# Altium schematic sheet 2_Power.SchDoc(2_Power)
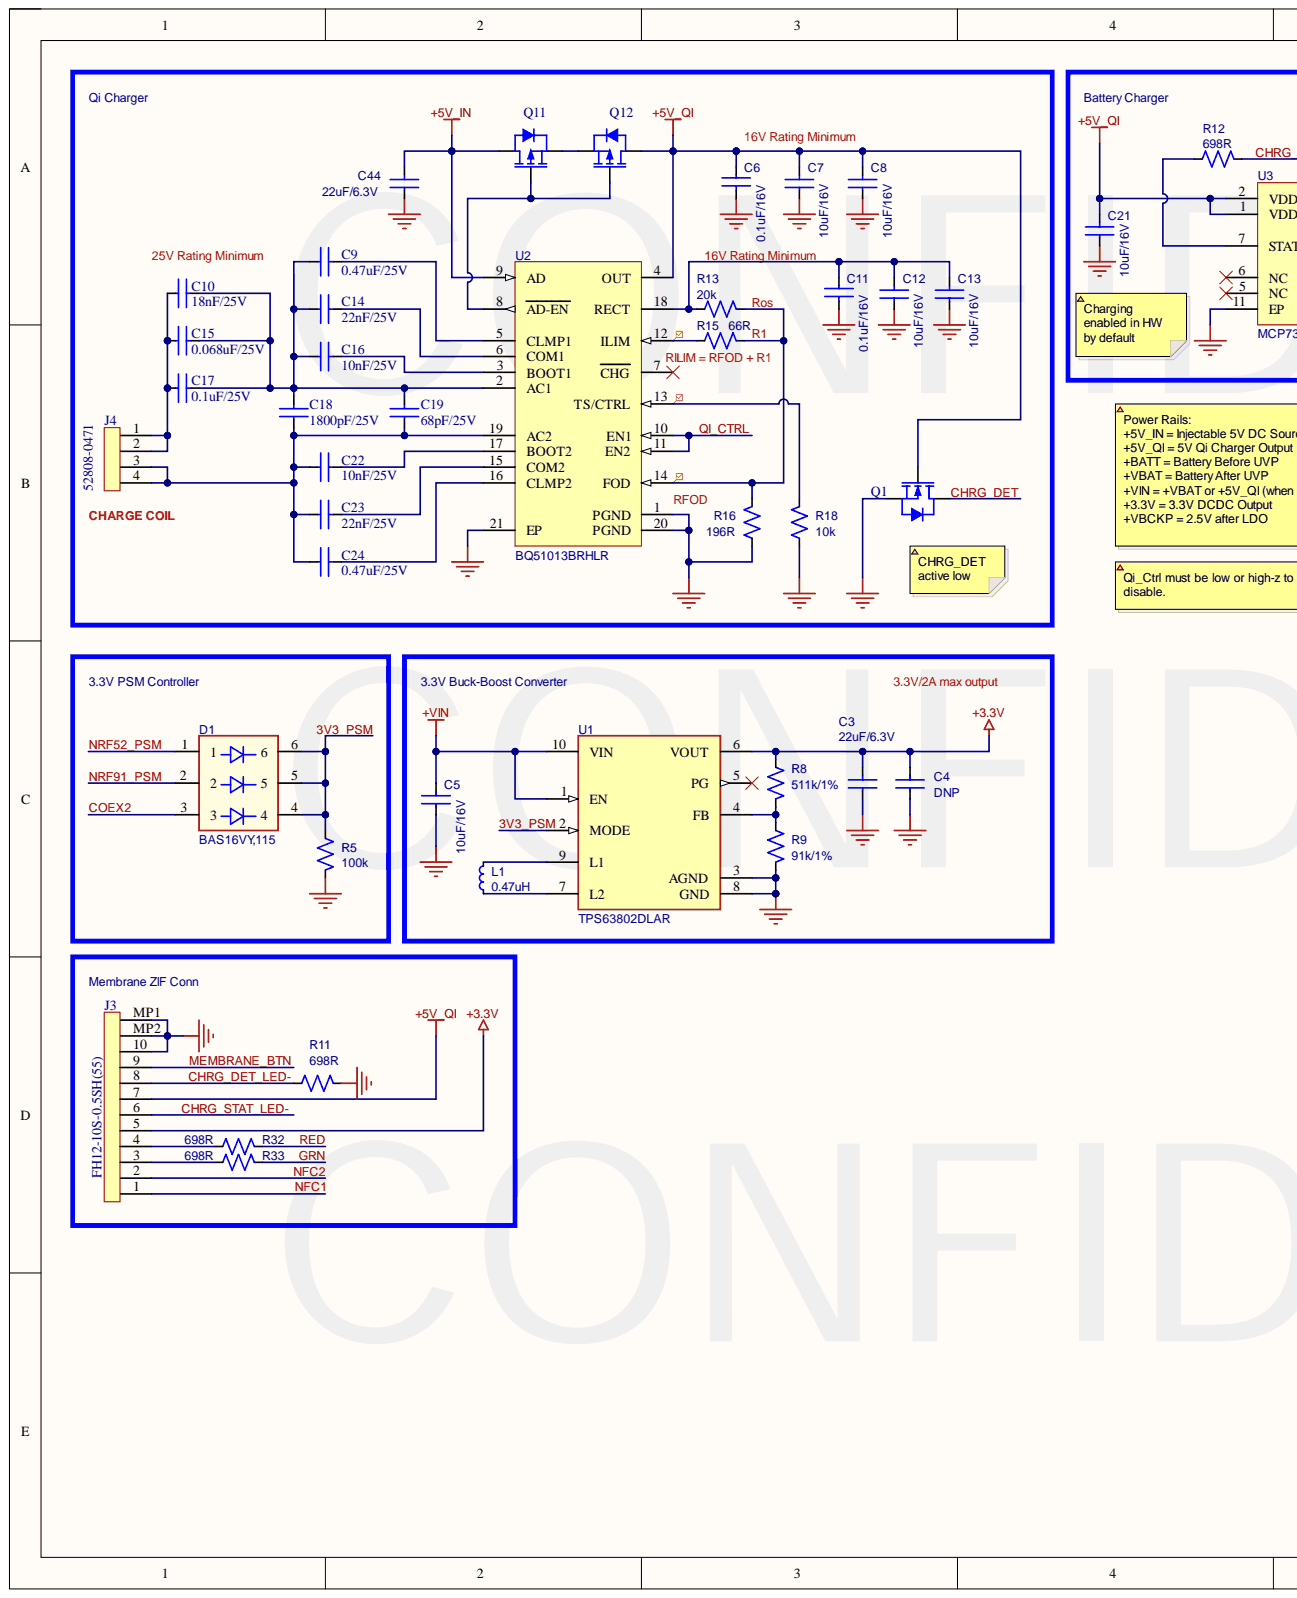
[diagram p0: 2_Power.SchDoc, left]
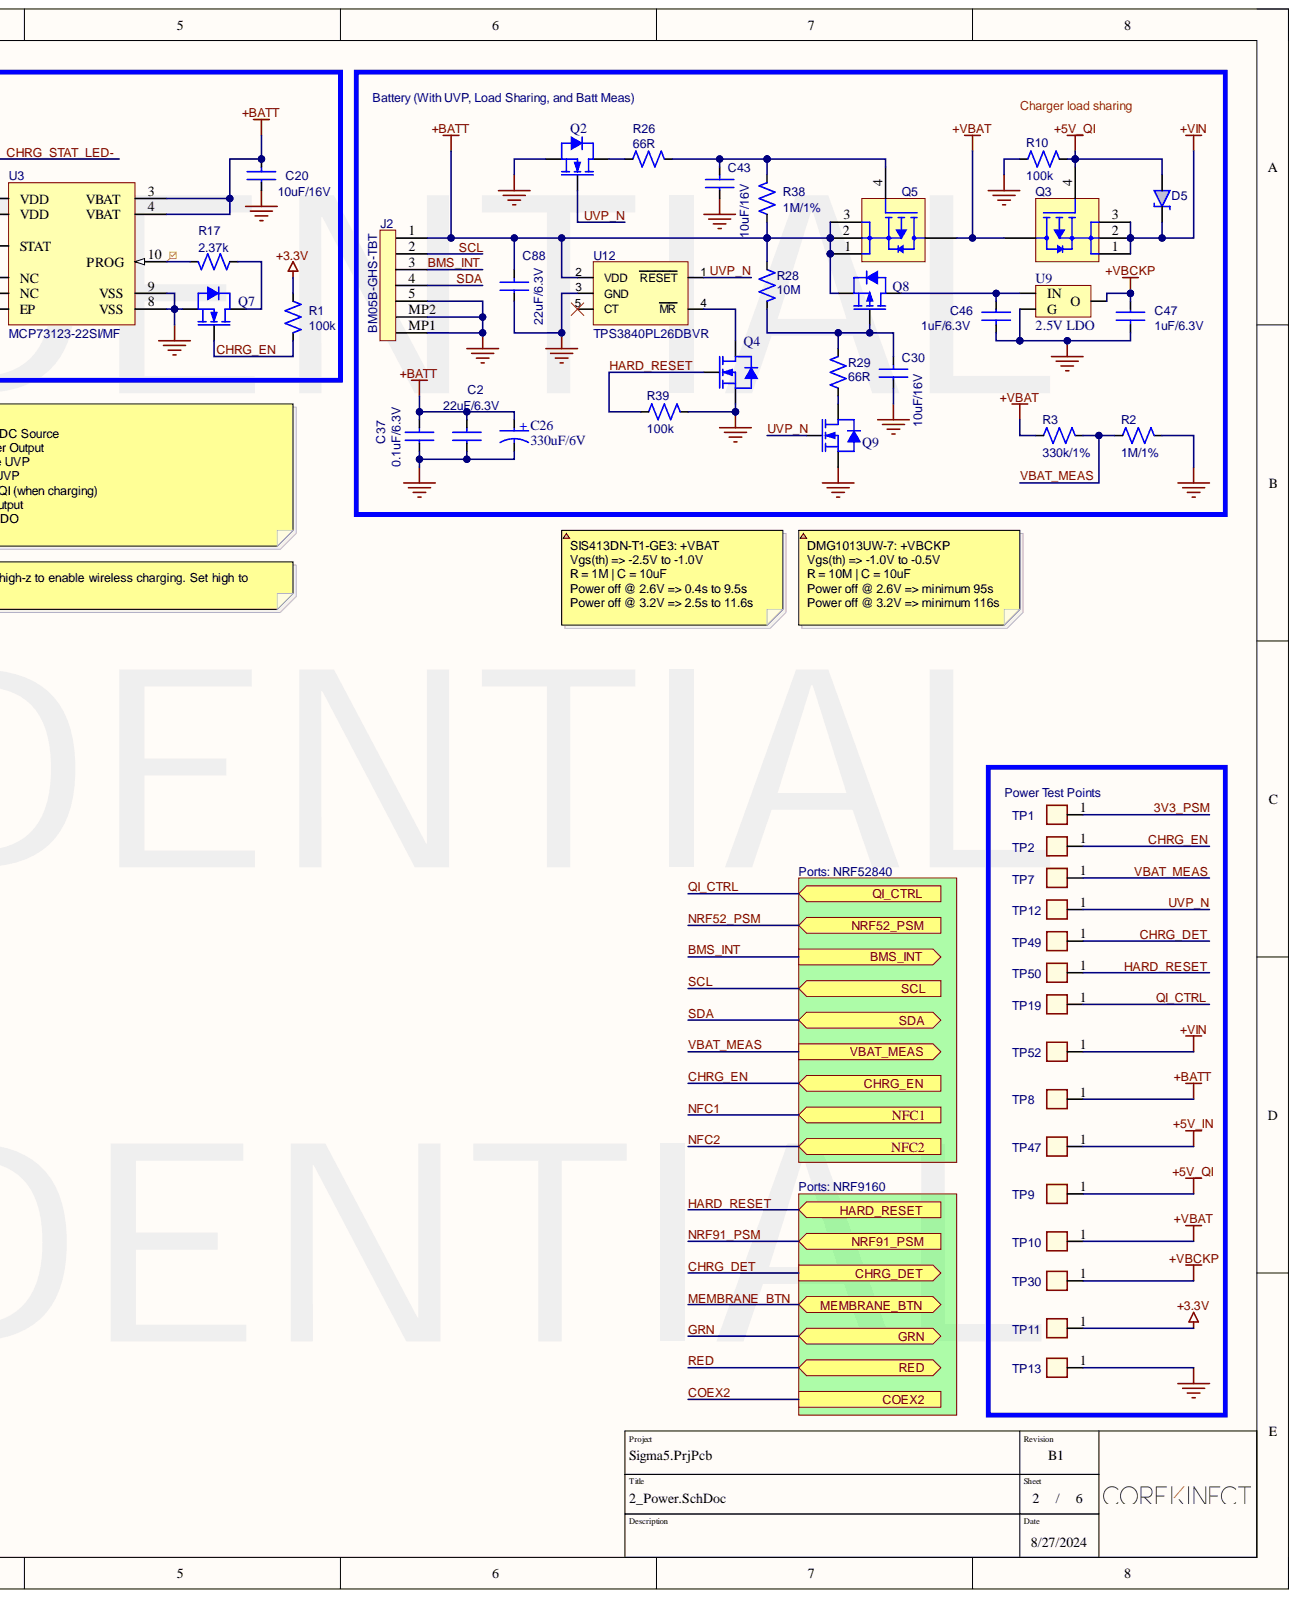
[diagram p1: 2_Power.SchDoc, right]

## Parts
C2  22uF/6.3V  [CAP_0603]
C3  22uF/6.3V  [CAP_0603]
C4  DNP  [CAP_0603]
C5  10uF/16V  [CAP_0603]
C6  0.1uF/16V  [CAP_0402]
C7  10uF/16V  [CAP_0603]
C8  10uF/16V  [CAP_0603]
C9  0.47uF/25V  [CAP_0402]
C10  18nF/25V  [CAP_0402]
C11  0.1uF/16V  [CAP_0402]
C12  10uF/16V  [CAP_0603]
C13  10uF/16V  [CAP_0603]
C14  22nF/25V  [CAP_0402]
C15  0.068uF/25V  [CAP_0402]
C16  10nF/25V  [CAP_0402]
C17  0.1uF/25V  [CAP_0402]
C18  1800pF/25V  [CAP_0402]
C19  68pF/25V  [CAP_0402]
C20  10uF/16V  [CAP_0603]
C21  10uF/16V  [CAP_0603]
C22  10nF/25V  [CAP_0402]
C23  22nF/25V  [CAP_0402]
C24  0.47uF/25V  [CAP_0402]
C26  330uF/6V  [CAP_POLAR_1411]
C30  10uF/16V  [CAP_0603]
C37  0.1uF/6.3V  [CAP_0402]
C43  10uF/16V  [CAP_0603]
C44  22uF/6.3V  [CAP_0603]
C46  1uF/6.3V  [CAP_0402]
C47  1uF/6.3V  [CAP_0402]
C88  22uF/6.3V  [CAP_0603]
D1  BAS16VY,115  [SOT-363]
D5  B140HW-7  [SOD123]  (value not drawn)
J2  BM05B-GHS-TBT  [JST-BM05B-GHS-TBT_V]
J3  FH12-10S-0.5SH(55)  [Hirose_FH12-10S-0.5SH(55)]
J4  52808-0471  [Molex_0528080471]
L1  0.47uH  [TAYO-IND0315_V]
Q1  DMG1012UW-7  [SOT-323]  (value not drawn)
Q2  DMG1012UW-7  [SOT-323]  (value not drawn)
Q3  SIS413DN-T1-GE3  [POWERPAK_1212-8]
Q4  DMG1012UW-7  [SOT-323]  (value not drawn)
Q5  SIS413DN-T1-GE3  [POWERPAK_1212-8]
Q7  DMG1012UW-7  [SOT-323]  (value not drawn)
Q8  DMG1013UW-7  [SOT-323]
Q9  DMG1012UW-7  [SOT-323]  (value not drawn)
Q11  DMG1013UW-7  [SOT-323]
Q12  DMG1013UW-7  [SOT-323]
R1  100k  [RES_0402]
R2  1M/1%  [RES_0402]
R3  330k/1%  [RES_0402]
R5  100k  [RES_0402]
R8  511k/1%  [RES_0402]
R9  91k/1%  [RES_0402]
R10  100k  [RES_0402]
R11  698R  [RES_0402]
R12  698R  [RES_0402]
R13  20k  [RES_0402]
R15  66R  [RES_0402]
R16  196R  [RES_0402]
R17  2.37k  [RES_0402]
R18  10k  [RES_0402]
R26  66R  [RES_0402]
R28  10M  [RES_0402]
R29  66R  [RES_0402]
R32  698R  [RES_0402]
R33  698R  [RES_0402]
R38  1M/1%  [RES_0402]
R39  100k  [RES_0402]
TP1  TP  [SMT_TP35_SM]
TP2  TP  [SMT_TP35_SM]
TP7  TP  [SMT_TP35_SM]
TP8  TP  [SMT_TP35_SM]
TP9  TP  [SMT_TP35_SM]
TP10  TP  [SMT_TP35_SM]
TP11  TP  [SMT_TP35_SM]
TP12  TP  [SMT_TP35_SM]
TP13  TP  [SMT_TP35_SM]
TP19  TP  [SMT_TP35_SM]
TP30  TP  [SMT_TP35_SM]
TP47  TP  [SMT_TP35_SM]
TP49  TP  [SMT_TP35_SM]
TP50  TP  [SMT_TP35_SM]
TP52  TP  [SMT_TP35_SM]
U1  TPS63802DLAR  [FP-DLA0010A-MFG]
U2  BQ51013BRHLR  [BQ51013BRHLR]
U3  MCP73123-22SI/MF  [DFN-MF10]
U9  2.5V LDO  Min Input Voltage: 2.5V  [SOT-23]
U12  TPS3840PL26DBVR  [SOT23-5P95_280X145XL45X37N]

## Ports
BMS_INT, CHRG_DET, CHRG_EN, COEX2, GRN, HARD_RESET, MEMBRANE_BTN, NFC1, NFC2, NRF52_PSM, NRF91_PSM, QI_CTRL, RED, SCL, SDA, VBAT_MEAS

## Nets
+3.3V: C3.2 C4.2 J3.5 R1.1 R8.1 TP11.1 U1.6(VOUT)
+5V_IN: C44.2 Q11.3 TP47.1 U2.9(AD)
+5V_QI: C6.1 C7.2 C8.2 C21.2 D5.2 J3.7 Q1.1 Q3.4 Q12.3 R10.1 TP9.1 U2.4(OUT) U3.1(VDD) U3.2(VDD)
+BATT: C2.2 C20.2 C26.1 C37.2 C88.2 J2.1 Q5.1 Q5.2 Q5.3 Q8.2 R28.1 R38.1 TP8.1 U3.3(VBAT) U3.4(VBAT) U12.2(VDD)
+VBAT: Q3.5-6-7-8 Q5.5-6-7-8 R3.1 TP10.1
+VBCKP: C47.1 TP30.1 U9.2
+VIN: C5.2 D5.1 Q3.1 Q3.2 Q3.3 TP52.1 U1.1(EN) U1.10(VIN)
GND: C2.1 C3.1 C4.1 C5.1 C6.2 C7.1 C8.1 C11.2 C12.1 C13.1 C20.1 C21.1 C26.2 C30.1 C37.1 C43.1 C44.1 C46.2 C47.2 C88.1 J2.5 J2.MP1 J2.MP2 J3.10 J3.MP1 J3.MP2 Q1.2 Q2.2 Q4.2 Q7.2 Q9.2 R2.2 R5.2 R9.2 R10.2 R11.2 R16.1 R18.2 R39.2 TP13.1 U1.3(AGND) U1.8(GND) U2.1(PGND) U2.20(PGND) U2.21(EP) U3.8(VSS) U3.9(VSS) U3.11(EP) U9.1 U12.3(GND)
3V3_PSM: D1.4 D1.5 D1.6 R5.1 TP1.1 U1.2(MODE)
BMS_INT: J2.3 ; port BMS_INT
CHRG_DET: Q1.3 TP49.1 ; port CHRG_DET
CHRG_DET_LED-: J3.8 R11.1
CHRG_EN: Q7.1 R1.2 TP2.1 ; port CHRG_EN
CHRG_STAT_LED-: J3.6 R12.2
COEX2: D1.3 ; port COEX2
GRN: R33.2 ; port GRN
HARD_RESET: Q4.1 R39.1 TP50.1 ; port HARD_RESET
MEMBRANE_BTN: J3.9 ; port MEMBRANE_BTN
NFC1: J3.1 ; port NFC1
NFC2: J3.2 ; port NFC2
NRF52_PSM: D1.1 ; port NRF52_PSM
NRF91_PSM: D1.2 ; port NRF91_PSM
QI_CTRL: TP19.1 U2.10(EN1) U2.11(EN2) ; port QI_CTRL
RED: R32.2 ; port RED
SCL: J2.2 ; port SCL
SDA: J2.4 ; port SDA
UVP_N: Q2.1 Q9.1 TP12.1 U12.1(RESET)
VBAT_MEAS: R2.1 R3.2 TP7.1 ; port VBAT_MEAS
NetC9_1: C9.1 C10.2 C14.1 C15.2 C16.1 C17.2 C18.2 C19.2 U2.2(AC1)
NetC9_2: C9.2 U2.5(CLMP1)
NetC10_1: C10.1 C15.1 C17.1 J4.1 J4.2
NetC11_1: C11.1 C12.2 C13.2 R13.1 U2.18(RECT)
NetC14_2: C14.2 U2.6(COM1)
NetC16_2: C16.2 U2.3(BOOT1)
NetC18_1: C18.1 C19.1 C22.1 C23.1 C24.1 J4.3 J4.4 U2.19(AC2)
NetC22_2: C22.2 U2.17(BOOT2)
NetC23_2: C23.2 U2.15(COM2)
NetC24_2: C24.2 U2.16(CLMP2)
NetC30_2: C30.2 Q8.1 R28.2 R29.2
NetC43_2: C43.2 Q5.4 R26.2 R38.2
NetC46_1: C46.1 Q8.3 U9.3
NetJ3_3: J3.3 R33.1
NetJ3_4: J3.4 R32.1
NetL1_1: L1.1 U1.7(L2)
NetL1_2: L1.2 U1.9(L1)
NetQ2_3: Q2.3 R26.1
NetQ4_3: Q4.3 U12.4(MR)
NetQ7_3: Q7.3 R17.1
NetQ9_3: Q9.3 R29.1
NetQ11_1: Q11.1 Q12.1 U2.8(AD-EN)
NetQ11_2: Q11.2 Q12.2
NetR8_2: R8.2 R9.1 U1.4(FB)
NetR12_1: R12.1 U3.7(STAT)
NetR13_2: R13.2 R15.2 R16.2 U2.14(FOD)
NetR15_1: R15.1 U2.12(ILIM)
NetR17_2: R17.2 U3.10(PROG)
NetR18_1: R18.1 U2.13(TS/CTRL)

Not connected: U1 5(PG); U2 7(CHG); U3 5(NC) 6(NC); U12 5(CT)

## Library data of the parts
C2-C5 C7 C8 C12 C13 C20 C21 C30 C43 C44 C88 = CAP_0603: Component Kind: Standard; Package / Case: 0603; Library Name: CoreKinectIntegratedLibrary.IntLib
C6 C9-C11 C14-C19 C22-C24 C37 C46 C47 = CAP_0402: Component Kind: Standard; Package / Case: 0402; Library Name: CoreKinectIntegratedLibrary.IntLib
C26 = CAP_POLAR_1411: Component Kind: Standard; Package / Case: 0201; Library Name: CoreKinectIntegratedLibrary.IntLib
D1 = BAS16VY,115: Diode Switching 100V 0.2A Automotive 6-Pin TSSOP T/R; Manufacturer 1: Nexperia USA Inc.; Component Kind: Standard; Manufacturer Part Number 1: BAS16VY,115; Pricing 1: 1=0.36000, 10=0.29700, 25=0.24840, 100=0.12140, 250=0.11952, 500=0.10130, 1000=0.07038 (USD); RoHS: No; Stock 1: 19076; Supplier 1: Digi-Key; Supplier Part Number 1: 1727-6171-1-ND; Library Name: CoreKinectIntegratedLibrary.IntLib
D5 = B140HW-7: Diode Schottky 40V 1A 2Pin SOD123; Manufacturer 1: Diodes; Element Configuration: Single; Forward Voltage: 550mV; Max Forward Surge Current (Ifsm): 16A; Max Power Dissipation: 410mW; Max Reverse Current: 40uA; Polarity: Standard; Average Rectified Current: 1A; Category: Rectifier Diodes; Component Kind: Standard; ComponentLink1Description: https://datasheet.ciiva.com/25946/ds30670-25946913.pdf?src-supplier=Avnet+Europe; ComponentLink1URL: https://datasheet.ciiva.com/25946/ds30670-25946913.pdf?src-supplier=Avnet+Europe; ComponentLink2Description: https://datasheet.ciiva.com/4715/ds30670-86720-4715619.pdf?src-supplier=Mouser; ComponentLink2URL: https://datasheet.ciiva.com/4715/ds30670-86720-4715619.pdf?src-supplier=Mouser; ComponentLink3Description: https://datasheet.ciiva.com/26788/b140hw-7-26788582.pdf?src-supplier=IIIC; ComponentLink3URL: https://datasheet.ciiva.com/26788/b140hw-7-26788582.pdf?src-supplier=IIIC; ComponentLink4Description: https://datasheet.ciiva.com/912/ds30670-912807.pdf?src-supplier=Digi-Key; ComponentLink4URL: https://datasheet.ciiva.com/912/ds30670-912807.pdf?src-supplier=Digi-Key; ComponentLink5Description: https://datasheet.ciiva.com/912/ds30670-912808.pdf?src-supplier=Digi-Key; ComponentLink5URL: https://datasheet.ciiva.com/912/ds30670-912808.pdf?src-supplier=Digi-Key; ComponentLink6Description: https://datasheet.ciiva.com/912/ds30670-912809.pdf?src-supplier=Digi-Key; ComponentLink6URL: https://datasheet.ciiva.com/912/ds30670-912809.pdf?src-supplier=Digi-Key; ComponentLink7Description: https://datasheet.ciiva.com/8301/ds30670-8301643.pdf?src-supplier=Avnet; ComponentLink7URL: https://datasheet.ciiva.com/8301/ds30670-8301643.pdf?src-supplier=Avnet; ComponentLink8Description: https://datasheet.ciiva.com/8303/ds30670-8303271.pdf?src-supplier=Avnet; ComponentLink8URL: https://datasheet.ciiva.com/8303/ds30670-8303271.pdf?src-supplier=Avnet; ComponentLink9Description: https://datasheet.ciiva.com/1177/ds30670-1177415.pdf?src-supplier=Element14; ComponentLink9URL: https://datasheet.ciiva.com/1177/ds30670-1177415.pdf?src-supplier=Element14; ComponentLink10Description: https://datasheet.ciiva.com/15220/getdatasheetpartid-245799-15220198.pdf?src-supplier=Verical; ComponentLink10URL: https://datasheet.ciiva.com/15220/getdatasheetpartid-245799-15220198.pdf?src-supplier=Verical; Contact Plating: Tin,Matte; Current Rating: 1A; Forward Current: 1A; Height: 1.25mm; Lead Free: Lead Free; Length: 2.85mm; Manufacturer Part Number 1: B140HW-7; Max Operating Temperature: 125°C; Max Repetitive Reverse Voltage (Vrrm): 40V; Max Reverse Voltage (DC): 40V; Min Operating Temperature: -65°C; Mount: Surface Mount; Number of Pins: 2; Output Current: 1A; Package Quantity: 3000; Packaging: Tape and Reel; Peak Non-Repetitive Surge Current: 16A; Pricing 1: 3000=0.08800, 6000=0.07920, 15000=0.07040, 30000=0.06680 (USD); Radiation Hardening: No; REACH SVHC: No SVHC; RoHS: Yes; RoHS Compliant: Yes; Series: -; Stock 1: 84000; Supplier 1: Digi-Key; Supplier Part Number 1: B140HWDITR-ND; Voltage Rating (DC): 40V; Weight: 0.000353oz; Width: 1.7mm; Library Name: CoreKinectIntegratedLibrary.IntLib
J2 = BM05B-GHS-TBT(LF)(SN)(N): Conn Shrouded Header (4 Sides) HDR 5 POS 1.25mm Solder ST Top Entry SMD T/R; Manufacturer 1: JST; Connector Type: Outer Shroud Contact; Number of Positions: 5; Pitch: 0.049inch; Category: Headers and Wire Housings; Color: Natural; Component Kind: Standard; ComponentLink1Description: http://www.jst-mfg.com/product/pdf/eng/eGH.pdf?src-supplier=Digi-Key; ComponentLink1URL: http://www.jst-mfg.com/product/pdf/eng/eGH.pdf?src-supplier=Digi-Key; Contact Plating: Tin; Fastening Type: Ramp; Lead Free: Lead Free; Manufacturer Part Number 1: BM05B-GHS-TBT(LF)(SN)(N); Mount: Surface Mount; Number of Pins: 5; Number of Rows: 1; Packaging: Cut Tape; Pricing 1: 1=0.48000, 10=0.41200, 100=0.32060, 500=0.27484, 1000=0.22903 (USD); RoHS: Yes; Stock 1: 30; Supplier 1: Digi-Key; Supplier Part Number 1: 455-1581-1-ND; Termination: Solder; Library Name: CoreKinectIntegratedLibrary.IntLib
J3 = FH12-10S-0.5SH(55): FFC, 0.5mm Pitch, Bottom Contact, 10way; Manufacturer: Hirose; Actuator Color: Brown; Actuator Material: Polyphenylene; Contact Resistance: 50mR; Current Rating: 500mA; Gender: Female; Insulation Resistance: 500MR; Mating Cycles: 20; Max Current Rating: 400mA; Max Voltage Rating (AC): 50V; Number of Contacts: 10; Wire/Cable Type: Straight; Category: FFC / FPC; Color: Beige,Brown; Component Kind: Standard; ComponentLink1Description: https://datasheet.ciiva.com/11745/0900766b80d985fc-11745079.pdf?src-supplier=Rs; ComponentLink1URL: https://datasheet.ciiva.com/11745/0900766b80d985fc-11745079.pdf?src-supplier=Rs; ComponentLink2Description: https://datasheet.ciiva.com/15176/getdatasheetpartid-470028-15176901.pdf?src-supplier=Verical; ComponentLink2URL: https://datasheet.ciiva.com/15176/getdatasheetpartid-470028-15176901.pdf?src-supplier=Verical; ComponentLink3Description: https://datasheet.ciiva.com/7661/58803-7661366.pdf?src-supplier=Element14; ComponentLink3URL: https://datasheet.ciiva.com/7661/58803-7661366.pdf?src-supplier=Element14; ComponentLink4Description: https://datasheet.ciiva.com/22630/58803-22630330.pdf?src-supplier=Farnell; ComponentLink4URL: https://datasheet.ciiva.com/22630/58803-22630330.pdf?src-supplier=Farnell; Contact Location: Bottom; Contact Material: Bronze; Contact Plating: Gold; Depth: 5.6mm; Flammability Rating: UL94 V-0; Housing Color: Beige; Housing Material: Polyamide; Lead Free: Lead Free; Lead Pitch: 0.5mm; Length: 9.1mm; Manufacturer Part Number: FH12-10S-0.5SH(55); Material: Polyamide; Max Operating Temperature: 70°C; Min Operating Temperature: -40°C; Mount: Surface Mount; Number of Positions: 10; Number of Rows: 1; Orientation: Right Angle; Package Quantity: 2000; Packaging: Tape and Reel; Pitch: 0.5mm; Pricing 1: 1=1.61, 10=1.4, 25=1.3408, 50=1.311, 100=1.2514, 250=1.1322, 500=1.04282, 1000=0.89385 (USD); Radiation Hardening: No; Reach SVHC Compliant: No; RoHS: Yes; RoHS Compliant: Yes; Series: FH12; Stock 1: 44403; Supplier 1: Digi-Key; Supplier Part Number 1: HFJ110DKR-ND; Termination: Solder; Voltage Rating: 50V; Voltage Rating (AC): 50V; Width: 9.1mm; Library Name: CoreKinectIntegratedLibrary.IntLib
J4 = MOLEX_52808-0471: FFC/FPC SMT Series 1mm Pitch 4 Way Straight SMT Female FPC Conn; Vertical Contact; Manufacturer: Molex; Current Rating: 500mA; Number of Circuits: 4; Voltage Rating: 125V; Wire/Cable Type: Flat Flex; Category: FFC / FPC; Component Kind: Standard; ComponentLink1Description: https://datasheet.ciiva.com/30115/528080871-sd-30115762.pdf?src-supplier=Onlinecomponents.com; ComponentLink1URL: https://datasheet.ciiva.com/30115/528080871-sd-30115762.pdf?src-supplier=Onlinecomponents.com; ComponentLink2Description: https://datasheet.ciiva.com/13680/528080471-sd-208534-13680495.pdf?src-supplier=Mouser; ComponentLink2URL: https://datasheet.ciiva.com/13680/528080471-sd-208534-13680495.pdf?src-supplier=Mouser; ComponentLink3Description: https://datasheet.ciiva.com/10366/528080871-sd-10366796.pdf?src-supplier=Avnet; ComponentLink3URL: https://datasheet.ciiva.com/10366/528080871-sd-10366796.pdf?src-supplier=Avnet; ComponentLink4Description: https://datasheet.ciiva.com/24394/528080671-sd-24394517.pdf?src-supplier=Onlinecomponents.com; ComponentLink4URL: https://datasheet.ciiva.com/24394/528080671-sd-24394517.pdf?src-supplier=Onlinecomponents.com; Contact Material: Bronze; Contact Plating: Tin; Flammability Rating: UL94 V-0; Gender: Female; Housing Material: Polyphenylene; Manufacturer Part Number: 52808-0471; Max Operating Temperature: 80°C; Min Operating Temperature: -20°C; Mount: Surface Mount; Number of Contacts: 4; Number of Positions: 4; Number of Rows: 1; Orientation: Vertical; Package Quantity: 1000; Packaging: Tape and Reel; Pitch: 1mm; Pricing 1: 1=0.68, 10=0.57, 100=0.4433 (USD); Radiation Hardening: No; Reach SVHC Compliant: Unknown; RoHS: Yes; RoHS Compliant: Yes; Series: 52808; Stock 1: 69968; Supplier 1: Digi-Key; Supplier Part Number 1: WM11043DKR-ND; Termination: SMD/SMT; Voltage Rating (AC): 125V; Library Name: CoreKinectIntegratedLibrary.IntLib
L1 = LQH3NPZR47NGRL: Ind Chip Wirewound 0.47uH 30% 1212 Emboss T/R; Manufacturer 1: Murata; Core Material: Ferrite; Current Rating: 2.54A; DC Resistance (DCR): 56.4mR; Inductance: 470nH; Saturation Current: 2.82A; Self Resonant Frequency: 180MHz; Shielding: Shielded; Test Frequency: 1MHz; Case Code (Imperial): 1212; Case Code (Metric): 3030; Case/Package: 1212; Component Kind: Standard; ComponentLink1Description: http://search.murata.co.jp/Ceramy/image/img/P02/JELF243A-9130.pdf?src-supplier=Digi-Key; ComponentLink1URL: http://search.murata.co.jp/Ceramy/image/img/P02/JELF243A-9130.pdf?src-supplier=Digi-Key; Composition: Wirewound; Manufacturer Part Number 1: LQH3NPZR47NGRL; Max Operating Temperature: 85°C; Min Operating Temperature: -40°C; Mount: Surface Mount; Packaging: Cut Tape; Pricing 1: 1=0.44000, 10=0.38200, 100=0.27310, 500=0.22942, 1000=0.18573 (USD); Ratings: AEC-Q200; RoHS: No; Stock 1: 4130; Supplier 1: Digi-Key; Supplier Part Number 1: 490-15967-1-ND; Tolerance: 30%; Library Name: CoreKinectIntegratedLibrary.IntLib
Q1 Q2 Q4 Q7 Q9 = DMG1012UW-7: MOSFET N-CH 20V 1A SOT323; Manufacturer: Diodes Zetex; Drain to Source Resistance: 300mR; Fall Time: 12.3ns; Gate to Source Voltage (Vgs): 6V; Input Capacitance: 60.67pF; Max Power Dissipation: 290mW; Number of Channels: 1; Polarity: N-CHANNEL; Rds On Max: 450mR; Rise Time: 7.4ns; Turn-Off Delay Time: 26.7ns; Turn-On Delay Time: 5.1ns; Case/Package: SOT-323; Category: MOSFETs; Component Kind: Standard; ComponentLink1Description: https://datasheet.ciiva.com/4443/ds31859-90313-4443117.pdf?src-supplier=Mouser; ComponentLink1URL: https://datasheet.ciiva.com/4443/ds31859-90313-4443117.pdf?src-supplier=Mouser; ComponentLink2Description: https://datasheet.ciiva.com/11174/ds31859-11174966.pdf?src-supplier=Avnet; ComponentLink2URL: https://datasheet.ciiva.com/11174/ds31859-11174966.pdf?src-supplier=Avnet; ComponentLink3Description: https://datasheet.ciiva.com/6383/ds31859-6383798.pdf?src-supplier=Digi-Key; ComponentLink3URL: https://datasheet.ciiva.com/6383/ds31859-6383798.pdf?src-supplier=Digi-Key; ComponentLink4Description: https://datasheet.ciiva.com/6383/ds31859-6383800.pdf?src-supplier=Digi-Key; ComponentLink4URL: https://datasheet.ciiva.com/6383/ds31859-6383800.pdf?src-supplier=Digi-Key; Continuous Drain Current (ID): 1A; Drain to Source Voltage (Vdss): 20V; Height: 1mm; Lead Free: Lead Free; Length: 2.2mm; Manufacturer Part Number: DMG1012UW-7; Max Operating Temperature: 150°C; Min Operating Temperature: -55°C; Mount: Surface Mount; Number of Pins: 3; Package Quantity: 3000; Packaging: Tape and Reel; Pricing 1: 1=0.35, 10=0.283, 100=0.1499, 500=0.09862, 1000=0.06706 (USD); Radiation Hardening: No; Reach SVHC Compliant: Yes; RoHS: Yes; RoHS Compliant: Yes; Series: -; Stock 1: 770121; Supplier 1: Digi-Key; Supplier Part Number 1: DMG1012UW-7DICT-ND; Weight: 0.000212oz; Width: 1.35mm; Library Name: CoreKinectIntegratedLibrary.IntLib
Q3 Q5 = SIS413DN-T1-GE3: VISHAY - SIS413DN-T1-GE3 - Power MOSFET, P Channel, 30 V, 18 A, 0.0076 ohm, PowerPAK 1212, Surface Mount; Continuous Drain Current (ID): -18A; Drain to Source Breakdown Voltage: -30V; Drain to Source Resistance: 9.4mR; Element Configuration: Single; Fall Time: 8ns; Gate to Source Voltage (Vgs): 20V; Input Capacitance: 4.28nF; Max Power Dissipation: 52W; Rise Time: 11ns; Threshold Voltage: -2.5V; Turn-Off Delay Time: 45ns; Category: MOSFETs; Component Kind: Standard; ComponentLink1Description: https://datasheet.ciiva.com/18566/sis413dn-18566915.pdf?src-supplier=Digi-Key; ComponentLink1URL: https://datasheet.ciiva.com/18566/sis413dn-18566915.pdf?src-supplier=Digi-Key; ComponentLink2Description: https://datasheet.ciiva.com/24019/sis413dn-254110-24019036.pdf?src-supplier=Mouser; ComponentLink2URL: https://datasheet.ciiva.com/24019/sis413dn-254110-24019036.pdf?src-supplier=Mouser; ComponentLink3Description: https://datasheet.ciiva.com/18566/sis413dn-18566917.pdf?src-supplier=Digi-Key; ComponentLink3URL: https://datasheet.ciiva.com/18566/sis413dn-18566917.pdf?src-supplier=Digi-Key; ComponentLink4Description: https://datasheet.ciiva.com/30021/sis413dn-30021722.pdf?src-supplier=Avnet; ComponentLink4URL: https://datasheet.ciiva.com/30021/sis413dn-30021722.pdf?src-supplier=Avnet; Drain to Source Voltage (Vdss): -30V; Manufacturer: VISHAY; Manufacturer Part Number: SIS413DN-T1-GE3; Max Operating Temperature: 150°C; Min Operating Temperature: -55°C; Mount: Surface Mount; Mounting Type: Surface Mount; Number of Pins: 8; Package / Case: POWERPAK 1212-8; Package Quantity: 3000; Packaging: Tape and Reel; Power Dissipation: 52W; Pricing 1: 1=0.56000, 10=0.49500, 25=0.46520, 100=0.33750, 250=0.32552, 500=0.28200, 1000=0.24000 (USD); Radiation Hardening: No; Rds On Max: 9.4mR; REACH SVHC: No SVHC; RoHS: Yes; Stock 1: 26833; Supplier 1: Digi-Key; Library Name: CoreKinectIntegratedLibrary.IntLib
Q8 Q11 Q12 = DMG1013UW-7: DMG1013UW Series 20 V 750 mOhm P-Channel Enhancement Mode Mosfet - SOT-323; Manufacturer: Diodes; Continuous Drain Current (ID): 820mA; Drain to Source Resistance: 1.5R; Drain to Source Voltage (Vdss): 20V; Drain-source On Resistance-Max: 750mR; Fall Time: 20.7ns; Gate to Source Voltage (Vgs): 6V; Input Capacitance: 59.76pF; Max Power Dissipation: 310mW; Number of Channels: 1; Rise Time: 8.1ns; Threshold Voltage: -1V; Turn-Off Delay Time: 28.4ns; Turn-On Delay Time: 5.1ns; Case/Package: SOT-323; Category: MOSFETs; Component Kind: Standard; ComponentLink1Description: https://datasheet.ciiva.com/4442/ds31861-67449-4442919.pdf?src-supplier=Mouser; ComponentLink1URL: https://datasheet.ciiva.com/4442/ds31861-67449-4442919.pdf?src-supplier=Mouser; ComponentLink2Description: https://datasheet.ciiva.com/16369/ds31861-16369875.pdf?src-supplier=Future+Electronics; ComponentLink2URL: https://datasheet.ciiva.com/16369/ds31861-16369875.pdf?src-supplier=Future+Electronics; ComponentLink3Description: https://datasheet.ciiva.com/11174/ds31861-11174967.pdf?src-supplier=Avnet; ComponentLink3URL: https://datasheet.ciiva.com/11174/ds31861-11174967.pdf?src-supplier=Avnet; ComponentLink4Description: https://datasheet.ciiva.com/934/ds31861-934533.pdf?src-supplier=Digi-Key; ComponentLink4URL: https://datasheet.ciiva.com/934/ds31861-934533.pdf?src-supplier=Digi-Key; ComponentLink5Description: https://datasheet.ciiva.com/934/ds31861-934534.pdf?src-supplier=Digi-Key; ComponentLink5URL: https://datasheet.ciiva.com/934/ds31861-934534.pdf?src-supplier=Digi-Key; ComponentLink6Description: https://datasheet.ciiva.com/934/ds31861-934532.pdf?src-supplier=Digi-Key; ComponentLink6URL: https://datasheet.ciiva.com/934/ds31861-934532.pdf?src-supplier=Digi-Key; Height: 1mm; Lead Free: Lead Free; Length: 2.2mm; Manufacturer Part Number: DMG1013UW-7; Max Operating Temperature: 150°C; Min Operating Temperature: -55°C; Mount: Surface Mount; Number of Pins: 3; Package Quantity: 3000; Packaging: Tape and Reel; Polarity: P-CHANNEL; Pricing 1: 1=0.35, 10=0.283, 100=0.1499, 500=0.09862, 1000=0.06706 (USD); Radiation Hardening: No; Rds On Max: 750mR; Reach SVHC Compliant: No; RoHS: Yes; RoHS Compliant: Yes; Series: -; Stock 1: 419828; Supplier 1: Digi-Key; Supplier Part Number 1: DMG1013UW-7DIDKR-ND; Weight: 0.000212oz; Width: 1.35mm; Library Name: CoreKinectIntegratedLibrary.IntLib
R1-R3 R5 R8-R13 R15-R18 R26 R28 R29 R32 R33 R38 R39 = RES_0402: Component Kind: Standard; Package / Case: 0402; Library Name: CoreKinectIntegratedLibrary.IntLib
TP1 TP2 TP7-TP13 TP19 TP30 TP47 TP49 TP50 TP52 = CON1_1: PN: SMT_TP35; Component Kind: Standard
U1 = TPS63802DLAR: Conv DC to DC Single Boost/Buck-Boost/Buck 1.3V to 5.5V 10-Pin VSON-HR T/R; Manufacturer 1: Texas Instruments; Component Kind: Standard; Manufacturer Part Number 1: TPS63802DLAR; Pricing 1: 1=2.54000, 10=2.28400, 25=2.15520, 100=1.72400, 250=1.50852, 500=1.46540, 1000=1.16370 (USD); RoHS: No; Stock 1: 2822; Supplier 1: Digi-Key; Supplier Part Number 1: 296-TPS63802DLARCT-ND; Library Name: CoreKinectIntegratedLibrary.IntLib
U2 = BQ51013BRHLR: IC, WIRELESS PWR RX, QI COMPLIANT, 20QFN; IC Function:Wireless Power Receiver; Supply Voltage Min:4V; Supply Voltage Max:10V; IC Package Type:QFN; No. of Pins:20; Operating Temperature Min:0°C; Operating Temperature Max:125°C; ;RoHS Compliant: Yes; Manufacturer 1: Texas Instruments; Manufacturer Part Number 1: BQ51013BRHLT; Max Supply Voltage: 10V; Min Supply Voltage: 4V; Operating Supply Current: 8mA; Output Current: 1.5A; Output Power: 5W; Output Voltage: 5V; Case/Package: VQFN; Category: Power Management ICs; Component Kind: Standard; ComponentLink1Description: https://datasheet.ciiva.com/22691/1726308-22691764.pdf?src-supplier=Element14; ComponentLink1URL: https://datasheet.ciiva.com/22691/1726308-22691764.pdf?src-supplier=Element14; ComponentLink2Description: https://datasheet.ciiva.com/6602/bq51013b-6602582.pdf?src-supplier=Digi-Key; ComponentLink2URL: https://datasheet.ciiva.com/6602/bq51013b-6602582.pdf?src-supplier=Digi-Key; ComponentLink3Description: https://datasheet.ciiva.com/6602/bq51013b-6602583.pdf?src-supplier=Digi-Key; ComponentLink3URL: https://datasheet.ciiva.com/6602/bq51013b-6602583.pdf?src-supplier=Digi-Key; ComponentLink4Description: https://datasheet.ciiva.com/6602/bq51013b-6602581.pdf?src-supplier=Digi-Key; ComponentLink4URL: https://datasheet.ciiva.com/6602/bq51013b-6602581.pdf?src-supplier=Digi-Key; Contact Plating: Gold; Height: 0.95mm; Lead Free: Lead Free; Length: 4.65mm; Logic Function: Receiver; Max Operating Temperature: 150°C; Min Operating Temperature: -40°C; Mount: Surface Mount; Number of Pins: 20; Package Quantity: 250; Packaging: Tape and Reel; Pricing 1: 1=3.83000, 10=3.41800, 25=3.07560, 100=2.80240 (USD); REACH SVHC: No SVHC; RoHS: Yes; Stock 1: 2462; Supplier 1: Digi-Key; Supplier Part Number 1: 296-35638-1-ND; Weight: 0.002469oz; Width: 3.65mm; Library Name: CoreKinectIntegratedLibrary.IntLib
U3 = MCP73123-22SI/MF: Single cell,  6.5V OVP LiFePO4 battery charger,  3.6V regulation,  10 DFN 3x3mm TUB; Manufacturer 1: Microchip; Drain to Source Resistance: 350mR; Max Charge Current: 1.1A; Max Input Voltage: 18V; Max Output Voltage: 3.618V; Min Output Voltage: 3.582V; Min Supply Voltage: 4.2V; Number of Cells: 1; Operating Supply Current: 700uA; Case/Package: DFN; Category: Battery Management; Component Kind: Standard; ComponentLink1Description: https://datasheet.ciiva.com/1137/1791044-1137745.pdf?src-supplier=Element14; ComponentLink1URL: https://datasheet.ciiva.com/1137/1791044-1137745.pdf?src-supplier=Element14; ComponentLink2Description: https://datasheet.ciiva.com/15114/getdatasheetpartid-448067-15114742.pdf?src-supplier=Verical; ComponentLink2URL: https://datasheet.ciiva.com/15114/getdatasheetpartid-448067-15114742.pdf?src-supplier=Verical; ComponentLink3Description: https://datasheet.ciiva.com/17299/22191d-17299671.pdf?src-supplier=Avnet+Europe; ComponentLink3URL: https://datasheet.ciiva.com/17299/22191d-17299671.pdf?src-supplier=Avnet+Europe; ComponentLink4Description: https://datasheet.ciiva.com/16296/filehandler-aspxddocname-en544014-16296186.pdf?src-supplier=Future+Electronics; ComponentLink4URL: https://datasheet.ciiva.com/16296/filehandler-aspxddocname-en544014-16296186.pdf?src-supplier=Future+Electronics; ComponentLink5Description: https://datasheet.ciiva.com/3215/22191d-3215276.pdf?src-supplier=Avnet; ComponentLink5URL: https://datasheet.ciiva.com/3215/22191d-3215276.pdf?src-supplier=Avnet; ComponentLink6Description: https://datasheet.ciiva.com/1933/22191d-1933102.pdf?src-supplier=Digi-Key; ComponentLink6URL: https://datasheet.ciiva.com/1933/22191d-1933102.pdf?src-supplier=Digi-Key; Height: 0.88mm; Lead Free: Lead Free; Length: 3mm; Manufacturer Part Number 1: MCP73123-22SI/MF; Max Operating Temperature: 85°C; Max Output Current: 1.1A; Max Supply Voltage: 6.5V; Min Operating Temperature: -40°C; Mount: Surface Mount; Number of Channels: 1; Number of Pins: 10; Output Current: 1.1A; Output Voltage: 3.6V; Package Quantity: 120; Packaging: Rail/Tube; Pricing 1: 1=1.12000, 25=0.93000, 100=0.85000 (USD); Radiation Hardening: No; REACH SVHC: No SVHC; RoHS: Yes; Stock 1: 2388; Supplier 1: Digi-Key; Supplier Part Number 1: MCP73123-22SI/MF-ND; Voltage Rating: 3.618V; Width: 3mm; Library Name: CoreKinectIntegratedLibrary.IntLib
U9 = AP2138N-2.5TRG1: LDO Regulator Pos 2.5V 0.25A 3-Pin SOT-23 T/R; Manufacturer: Diodes; Accuracy: 2%; Dropout Voltage: 650mV; Max Input Voltage: 6V; Max Output Current: 250mA; Number of Outputs: 1; Output Type: Fixed; Polarity: Positive; Quiescent Current: 1uA; Case/Package: SOT-23-3; Category: Voltage Regulators - Linear; Component Kind: Standard; ComponentLink1Description: https://datasheet.ciiva.com/30016/ap2138-9-30016776.pdf?src-supplier=Avnet; ComponentLink1URL: https://datasheet.ciiva.com/30016/ap2138-9-30016776.pdf?src-supplier=Avnet; ComponentLink2Description: https://datasheet.ciiva.com/29568/ap2138-9-29568364.pdf?src-supplier=Digi-Key; ComponentLink2URL: https://datasheet.ciiva.com/29568/ap2138-9-29568364.pdf?src-supplier=Digi-Key; ComponentLink3Description: https://datasheet.ciiva.com/29568/ap2138-9-29568365.pdf?src-supplier=Digi-Key; ComponentLink3URL: https://datasheet.ciiva.com/29568/ap2138-9-29568365.pdf?src-supplier=Digi-Key; ComponentLink4Description: https://datasheet.ciiva.com/29568/ap2138-9-29568389.pdf?src-supplier=Digi-Key; ComponentLink4URL: https://datasheet.ciiva.com/29568/ap2138-9-29568389.pdf?src-supplier=Digi-Key; Manufacturer Part Number: AP2138N-2.5TRG1; Max Operating Temperature: 85°C; Min Operating Temperature: -40°C; Mount: Surface Mount; Number of Pins: 3; Number of Regulators: 1; Output Current: 250mA; Output Voltage: 2.5V; Package Quantity: 3000; Packaging: Tape and Reel; Pricing 1: 1=0.36, 10=0.294, 25=0.2692, 100=0.2004, 250=0.1816, 500=0.15028, 1000=0.11272 (USD); RoHS: Yes; RoHS Compliant: Yes; Series: -; Stock 1: 38415; Supplier 1: Digi-Key; Supplier Part Number 1: AP2138N-2.5TRG1DIDKR-ND; Library Name: CoreKinectIntegratedLibrary.IntLib
U12 = TPS3840PL26DBVR: Nanopower high-input voltage supervisor with manual reset and programmable-reset time delay. Push-pull, active-low, 2.6 V threshold.; Manufacturer 1: Texas Instruments; Component Kind: Standard; Manufacturer Part Number 1: TPS3840PL26DBVR; Pricing 1: 1=1.01000, 10=0.90400, 25=0.85800, 50=0.85800, 100=0.70500, 250=0.65900, 500=0.58200, 1000=0.46000, 3000=0.44400, 10000=0.44400 (USD); RoHS: Yes; Stock 1: 2756; Supplier 1: Mouser; Supplier Part Number 1: 595-TPS3840PL26DBVR; Library Name: CoreKinectIntegratedLibrary.IntLib
